# Altium schematic sheet RA_Local_Promotion_Board_2.SchDoc(RA_Local_Promotion_Board_2)
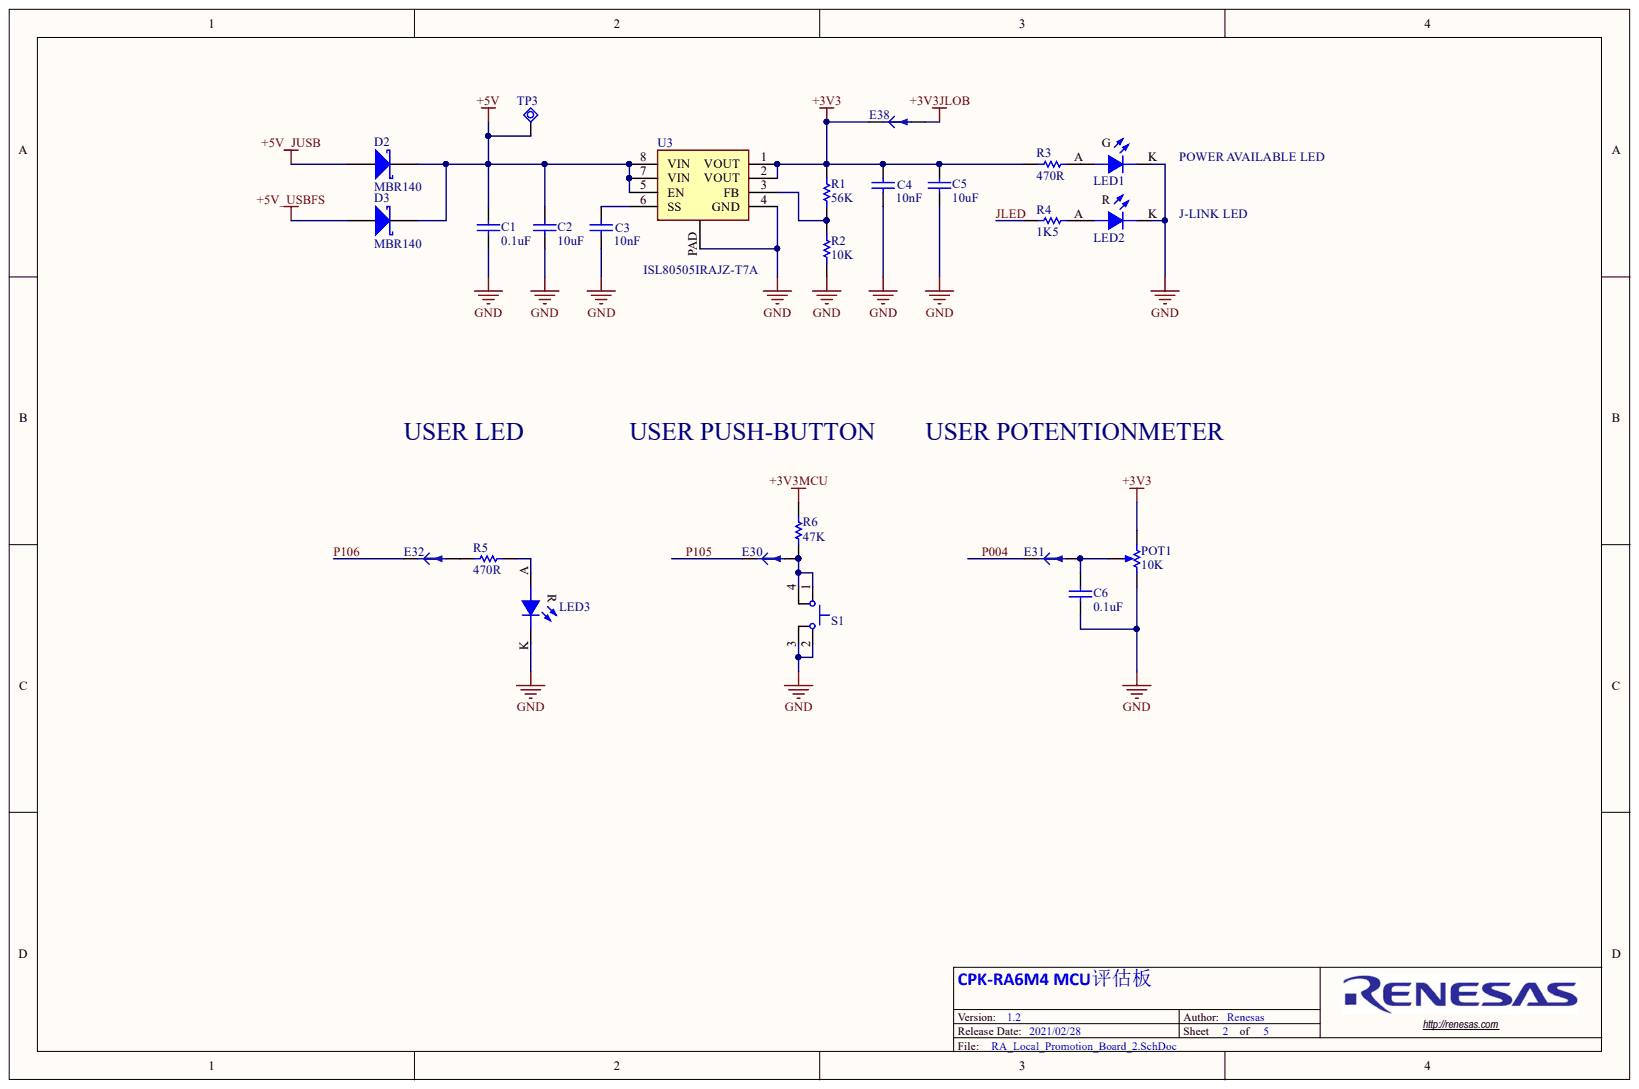
[diagram p0: RA_Local_Promotion_Board_2.SchDoc]

## Parts
C1  0.1uF  [CAPC0603-01]
C2  10uF  [CAPC0603-01]
C3  10nF  [CAPC0603-01]
C4  10nF  [CAPC0603-01]
C5  10uF  [CAPC0603-01]
C6  0.1uF  [CAPC0603-01]
D2  Display Name: MBR140  [ONSEMI_SOD-123LF]  (hidden comment: MBR140SFT1G)
D3  Display Name: MBR140  [ONSEMI_SOD-123LF]  (hidden comment: MBR140SFT1G)
E30  Jumper Trace Cut  [E_PadsTraceCut0805]  (value not drawn)  (no BOM)
E31  Jumper Trace Cut  [E_PadsTraceCut0805]  (value not drawn)  (no BOM)
E32  Jumper Trace Cut  [E_PadsTraceCut0805]  (value not drawn)  (no BOM)
E38  Jumper Trace Cut  [E_PadsTraceCut0805]  (value not drawn)  (no BOM)
LED1  LED Green  [LED_0603-GRN]  (value not drawn)
LED2  LED Red  [LED_0603-RED]  (value not drawn)
LED3  LED Red  [LED_0603-RED]  (value not drawn)
POT1  10K  [3x3 register]
R1  56K  [RESC0603-01]
R2  10K  [RESC0603-01]
R3  470R  [RESC0603-01]
R4  1K5  [RESC0603-01]
R5  470R  [RESC0603-01]
R6  47K  [RESC0603-01]
S1  Switch 4.5x4.5mm  [KEY A (4.5 X 4.5)]  (value not drawn)
TP3  Test Point  [TP A - Red]  (value not drawn)
U3  Display Name: ISL80505IRAJZ-T7A  [DFN8 (3x3) Pitch 0.65]  (hidden comment: ISL80510IRAJZ)

## Nets
+3V3: C4.2 C5.2 E38.1 POT1.1 R1.2 R3.1 U3.1(VOUT) U3.2(VOUT)
+3V3JLOB: E38.2
+3V3MCU: R6.2
+5V: C1.2 C2.2 D2.K D3.K TP3.1 U3.5(EN) U3.7(VIN) U3.8(VIN)
+5V_JUSB: D2.A
+5V_USBFS: D3.A
GND: C1.1 C2.1 C3.1 C4.1 C5.1 C6.1 LED1.K LED2.K LED3.K POT1.3 R2.1 S1.2 S1.3 U3.4(GND) U3.PAD
JLED: R4.1
P004: E31.1
P105: E30.1
P106: E32.1
NetC3_2: C3.2 U3.6(SS)
NetC6_2: C6.2 E31.2 POT1.2
NetE30_2: E30.2 R6.1 S1.1 S1.4
NetE32_2: E32.2 R5.1
NetLED1_A: LED1.A R3.2
NetLED2_A: LED2.A R4.2
NetLED3_A: LED3.A R5.2
NetR1_1: R1.1 R2.2 U3.3(FB)

## Library data of the parts
C1 C6 = CAP-0603-0.1uF: Ceramic Chip Capacitor 0603 0.1uF 50V; Manufacturer: MURATA; Mfg Part Number: GCM188L81H104KA57D; Dialectric: X8L; Tolerance: 10%; Capacitance: 0.1uF; Component Kind: Standard; Library Name: New Com.SchLib; Package: 0603; Voltage: 50V
C2 C5 = CAP-0603-10uF: Ceramic Chip Capacitor 0603 10uF 6.3V; Manufacturer: Samsung; Mfg Part Number: CL10A106MQ8NNNC; Dialectric: X5R; Tolerance: 20%; Capacitance: 10uF; Component Kind: Standard; Package: 0603; Voltage: 6.3V; Library Name: Synergy.SchLib
C3 C4 = CAP-0603-10nF: Ceramic Chip Capacitor 0603 10nF 50V; Manufacturer: MURATA; Mfg Part Number: GCD188R71H103KA01D; Dialectric: X7R; Tolerance: 10%; Capacitance: 10nF; Component Kind: Standard; Library Name: New Com.SchLib; Package: 0603; Voltage: 50V
D2 D3 = DIO-MBR140SFT1G: OnSemi Schottky Power Rectifier 40V 1A; Component Kind: Standard; Manufacturer: OnSemi; Mfg Part Number: MBR140SFT1G; Package: SOD-123FL; Library Name: Synergy.SchLib
E30-E32 E38 = E_JmpTraceCut: Pads on PCB with Trace Between for Cutting to isolate; Part Type: Jumper Trace Cut; Library Name: Synergy.SchLib
LED1 = LED-0603-Green: Lite-On LED Green Clear 0603; Mfg Part Number: LTST-C191KGKT; Serious P/N: LED-000004-01; Component Kind: Standard; Manufacturer: Lite-On; Package: 0603; Library Name: Synergy.SchLib
LED2 LED3 = LED-0603-Red: Lite-On LED Red Clear 0603; Mfg Part Number: LTST-C191KRKT; Component Kind: Standard; Manufacturer: Lite-On; Package: 0603; Library Name: Synergy.SchLib
POT1 = POT-10K: Bourns Single Turn Trimmer 10K; Mfg Part Number: 3352T-1-103LF; Tolerance: 20%; Component Kind: Standard; Manufacturer: Bourns; Package: BOURNS_3355T; Resistance: 10K; Library Name: Synergy.SchLib
R1 = RES-0603-56K: Chip Resistor Thick Film 0603 56K 1% 1/10W; Manufacturer: YAGEO; Mfg Part Number: RC0603FR-0756KL; Component Kind: Standard; Library Name: New Com.SchLib; Package: 0603; Power: 1/10W; Resistance: 56K; Tolerance: 1%
R2 = RES-0603-10K: Chip Resistor Thick Film 0603 10K 1% 1/10W; Manufacturer: YAGEO; Mfg Part Number: RC0603FR-0710KL; Component Kind: Standard; Library Name: New Com.SchLib; Package: 0603; Power: 1/10W; Resistance: 10K; Tolerance: 1%
R3 R5 = RES-0603-470R: Chip Resistor Thick Film 0603 470R 1% 1/10W; Manufacturer: YAGEO; Mfg Part Number: RC0603FR-07470RL; Component Kind: Standard; Library Name: New Com.SchLib; Package: 0603; Power: 1/10W; Resistance: 470R; Tolerance: 1%
R4 = RES-0603-1K5: Chip Resistor Thick Film 0603 1K5 1% 1/10W; Manufacturer: YAGEO; Mfg Part Number: RC0603FR-071K5L; Component Kind: Standard; Library Name: New Com.SchLib; Package: 0603; Power: 1/10W; Resistance: 1K5; Tolerance: 1%
R6 = RES-0603-47K: Chip Resistor Thick Film 0603 47K 1% 1/10W; Manufacturer: YAGEO; Mfg Part Number: RC0603FR-0747KL; Component Kind: Standard; Library Name: New Com.SchLib; Package: 0603; Power: 1/10W; Resistance: 47K; Tolerance: 1%
S1 = SWT-4.5x4.5mm: Push Button Switch 4.5 x 4.5mm 3.8mm High; Manufacturer: C&K; Mfg Part Number: PTS647SM38SMTR2 LFS; Component Kind: Standard; Library Name: New Com.SchLib
TP3 = CON-Test Point: Component Kind: Standard; Description: Test Point; Library Name: Synergy.SchLib
U3 = ICN-ISL80510: IC REG LINEAR POS ADJ 1A 8-DFN（3x3）; Manufacturer: Intersil; Mfg Part Number: ISL80510IRAJZ-T7A; Component Kind: Standard; Package: 8-VDFN; Library Name: Synergy.SchLib
